# Altium schematic sheet DC_DC_5V.SchDoc
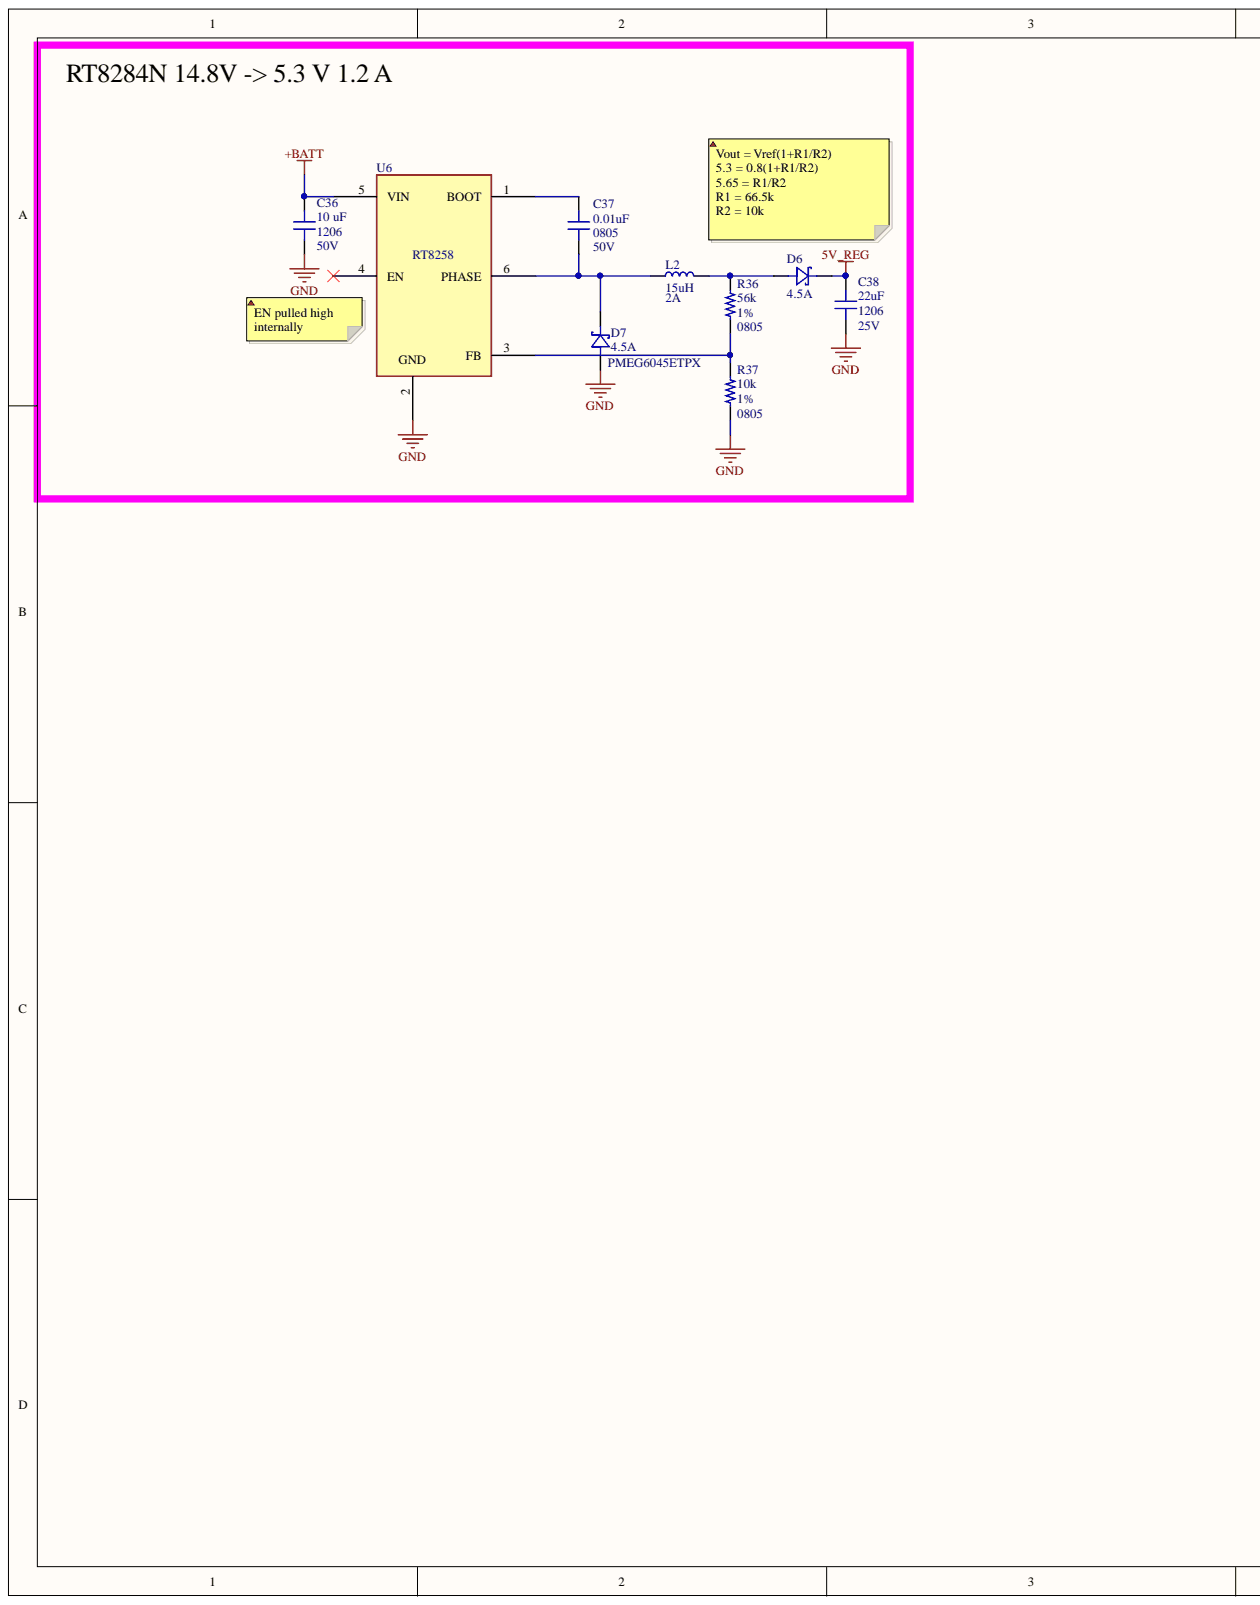
[diagram p0: DC_DC_5V.SchDoc, left]
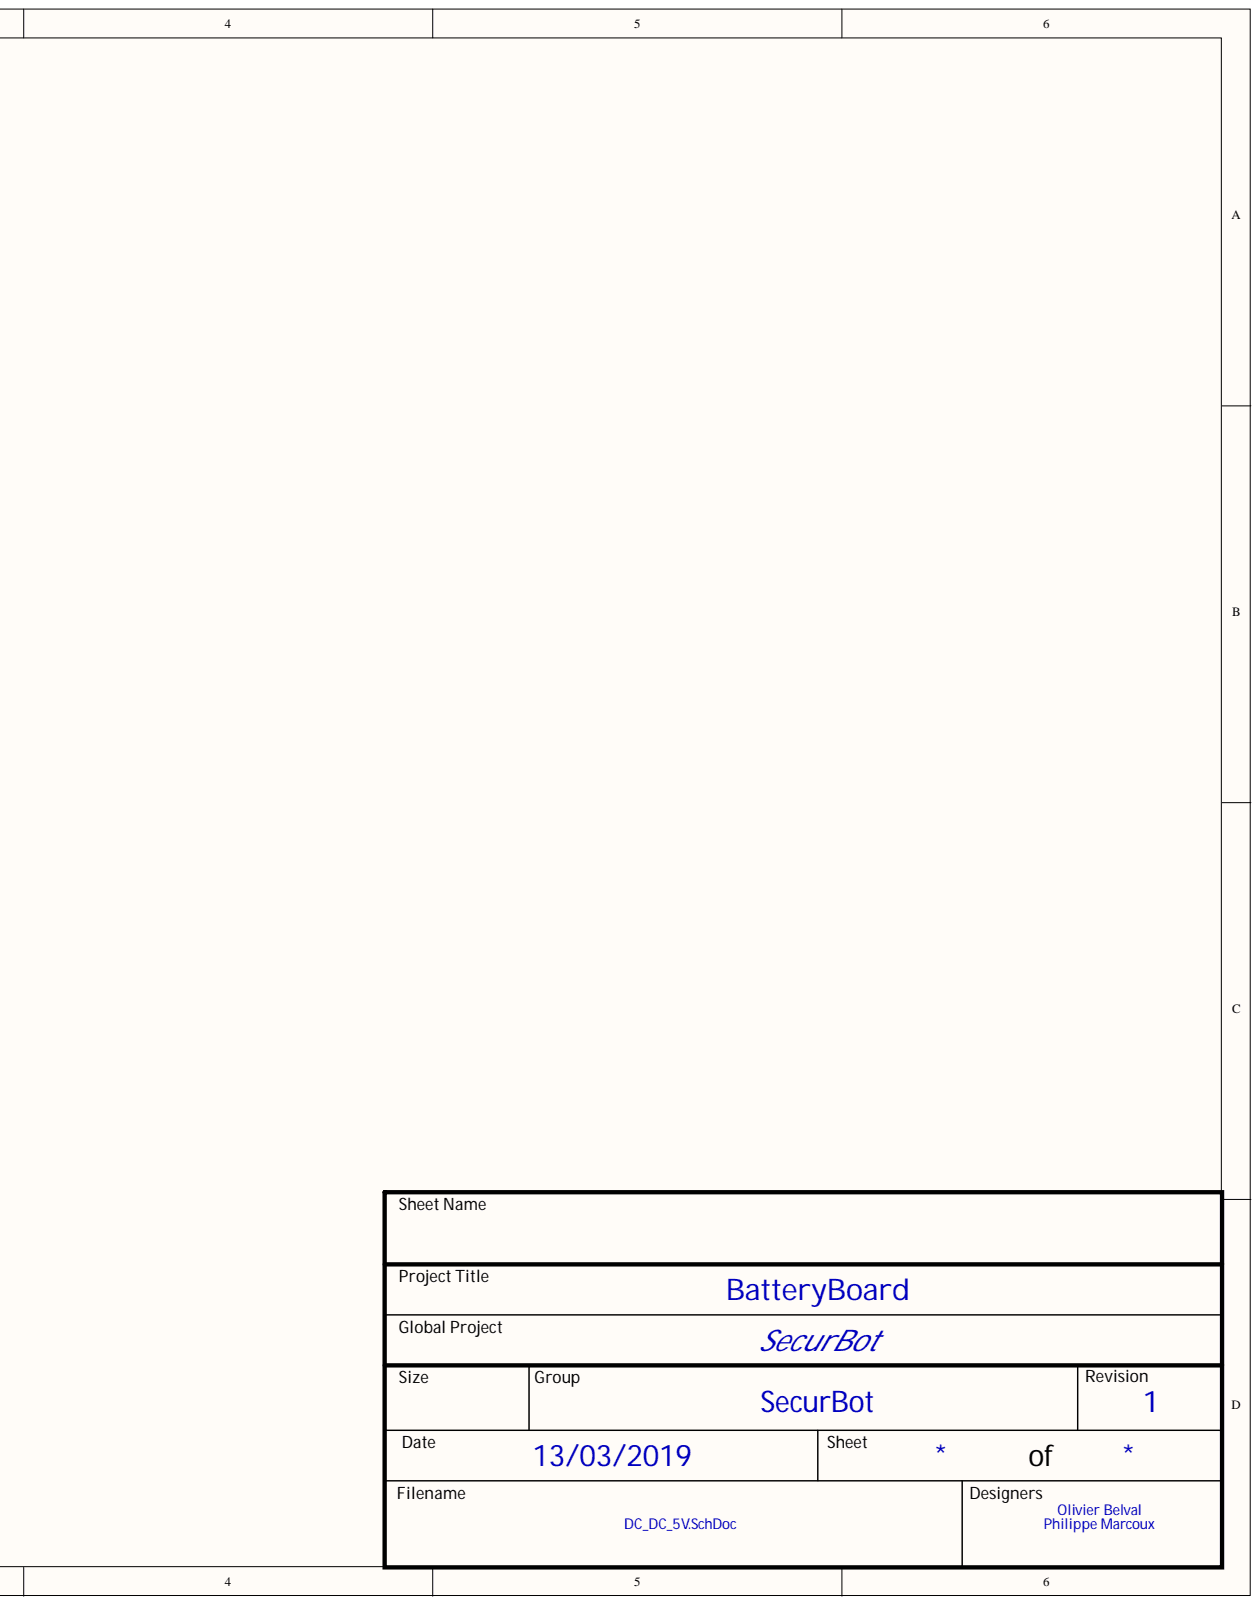
[diagram p1: DC_DC_5V.SchDoc, right]

## Parts
C36  value 10 uF  Package: 1206  Voltage: 50V  [CAP_1206]  (hidden comment: CAP - 1206)
C37  value 0.01uF  Package: 0805  Voltage: 50V  [CAP_0805]  (hidden comment: CAP - 0805)
C38  value 22uF  Package: 1206  Voltage: 25V  [CAP_1206]  (hidden comment: CAP - 1206)
D6  Current: 4.5A  [DO-214AC_SOD128]  (hidden comment: SCHOTTKEY)
D7  Current: 4.5A  Part number: PMEG6045ETPX  [DO-214AC_SOD128]  (hidden comment: SCHOTTKEY)
L2  value 15uH  Current: 2A  [NR5040T150M]  (hidden comment: IND)
R36  value 56k  Package: 0805  Tolerance: 1%  [FER_0805]  (hidden comment: RES - 0805)
R37  value 10k  Package: 0805  Tolerance: 1%  [FER_0805]  (hidden comment: RES - 0805)
U6  RT8258  [SOT23-6]

## Nets
5V_REG: C38.1 D6.2
+BATT: C36.1 U6.5(VIN)
GND: C36.2 C38.2 D7.1 R37.2 U6.2
NetC37_1: C37.1 U6.1(BOOT)
NetC37_2: C37.2 D7.2 L2.1 U6.6(PHASE)
NetD6_1: D6.1 L2.2 R36.1
NetR36_2: R36.2 R37.1 U6.3(FB)

Not connected: U6 4(EN)

## Library data of the parts
C36 = CAP - 1206: Part number: GRT31CR61H106ME01L; Component Kind: Standard; Description: CAP - 1206; Library Name: SecureBot.SchLib
C37 = CAP - 0805: Part number: CL21B103KBANNNC; Component Kind: Standard; Description: CAP - 0805; Library Name: SecureBot.SchLib
C38 = CAP - 1206: Part number: CL31A226KAHNNNE; Component Kind: Standard; Description: CAP - 1206; Library Name: SecureBot.SchLib
D6 = SCHOTTKEY: Part number: PMEG6045ETPX; Vf: Vf =0.33V; Component Kind: Standard; Library Name: SecureBot.SchLib
D7 = SCHOTTKEY: Vf: Vf =0.33V; Component Kind: Standard; Library Name: SecureBot.SchLib
R36 = RES - 0805: Part number: RC0805FR-0756KL; Watt: ? W; Component Kind: Standard; Description: RES - 0805; Library Name: SecureBot.SchLib
R37 = RES - 0805: Part number: RMCF0805FT10K0; Watt: ? W; Component Kind: Standard; Description: RES - 0805; Library Name: SecureBot.SchLib
L2 = IND: Component Kind: Standard; Description: IND; Package: ?; Part number: NR5040T150M; Library Name: SecureBot.SchLib
U6 = RT8258: Component Kind: Standard; Part number: RT8258; Library Name: SecureBot.SchLib
